# Altium schematic sheet esp32-dfplayer.SchDoc(esp32-dfplayer)
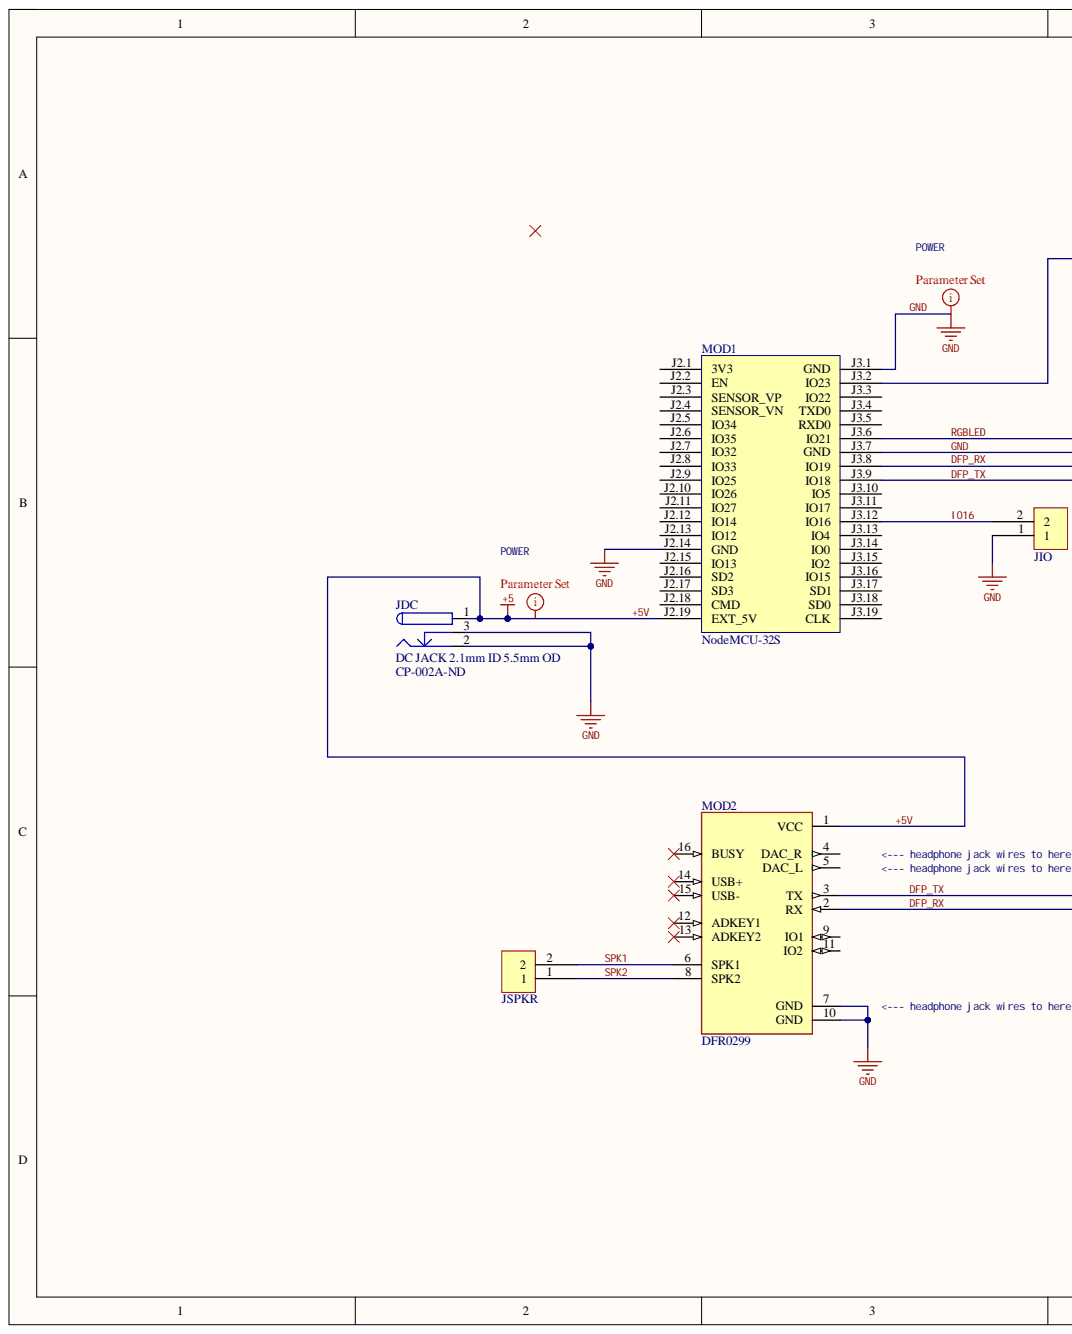
[diagram p0: esp32-dfplayer.SchDoc, left]
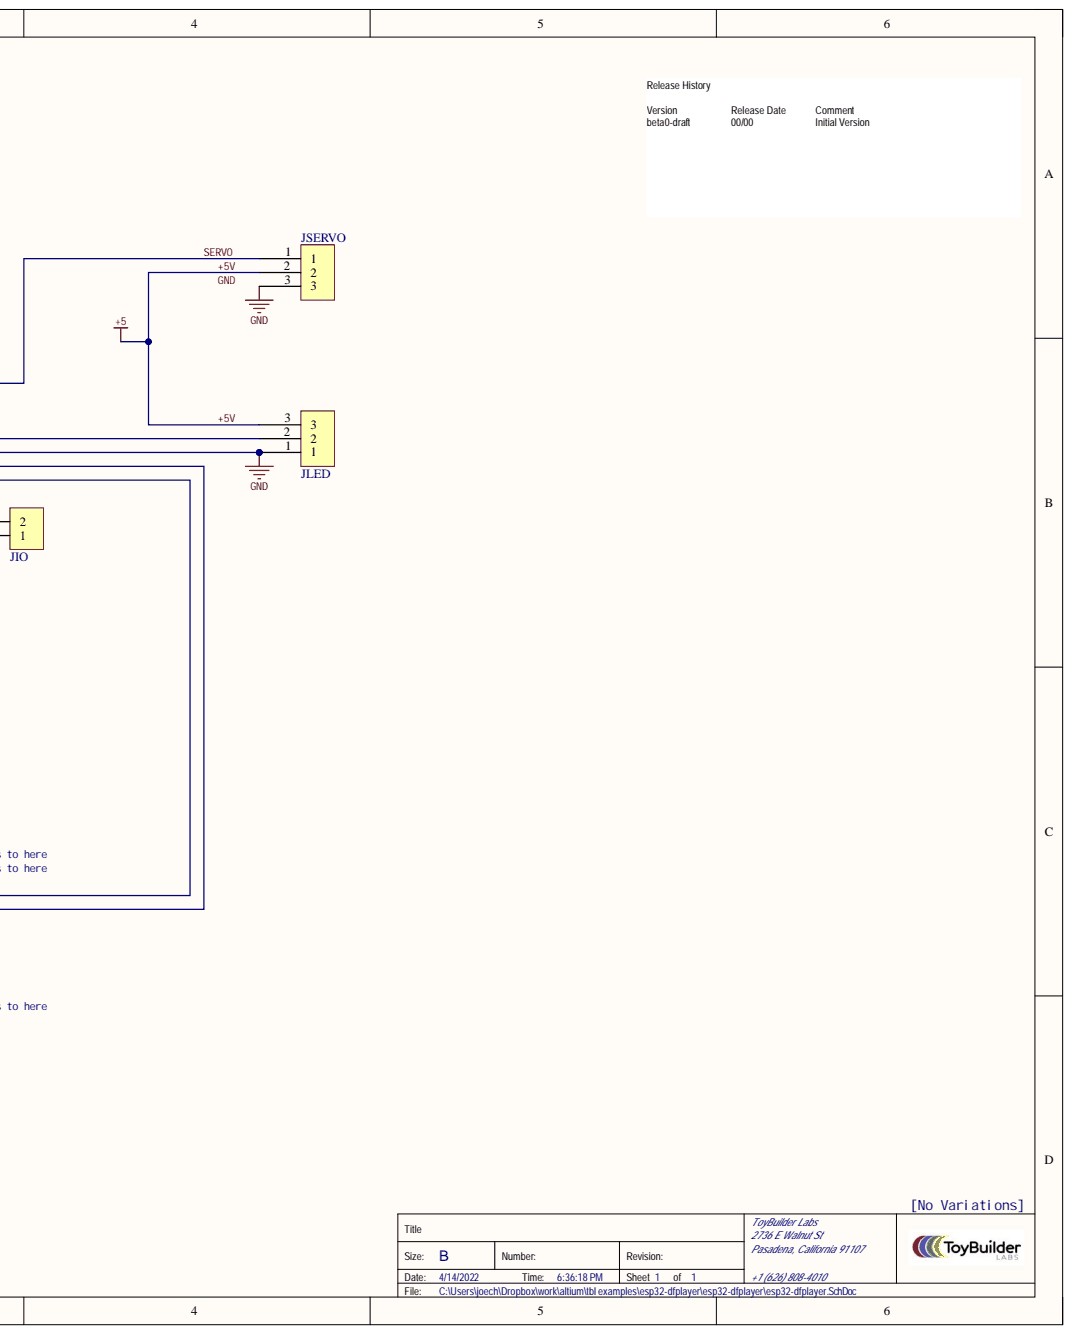
[diagram p1: esp32-dfplayer.SchDoc, right]

## Parts
JDC  DC JACK 2.1mm ID 5.5mm OD  Supplier Part Number 1: CP-002A-ND  [CUI_PJ002A_NOSLOT]
JIO  Header 2-Pin, 0.100 inch (0.230 inch head)  [HEADER_AA_002]  (value not drawn)
JLED  Header 3-Pin, 0.100 inch (0.230 inch head)  [HEADER_AA_003]  (value not drawn)
JSERVO  Header 3-Pin, 0.100 inch (0.230 inch head)  [HEADER_AA_003]  (value not drawn)
JSPKR  Header 2-Pin, 0.100 inch (0.230 inch head)  [HEADER_AA_002]  (value not drawn)
MOD1  NodeMCU-32S  [ESP32_NodeMCU-32S]
MOD2  DFR0299  [MODULE_DFR0299]

## Nets
+5V: JDC.1 JLED.3 JSERVO.2 MOD1.J2.19(EXT_5V) MOD2.1(VCC)
DFP_RX: MOD1.J3.8(IO19) MOD2.2(RX)
DFP_TX: MOD1.J3.9(IO18) MOD2.3(TX)
GND: JDC.2 JDC.3 JIO.1 JLED.1 JSERVO.3 MOD1.J2.14(GND) MOD1.J3.1(GND) MOD1.J3.7(GND) MOD2.7(GND) MOD2.10(GND)
IO16: JIO.2 MOD1.J3.12(IO16)
RGBLED: JLED.2 MOD1.J3.6(IO21)
SERVO: JSERVO.1 MOD1.J3.2(IO23)
SPK1: JSPKR.2 MOD2.6(SPK1)
SPK2: JSPKR.1 MOD2.8(SPK2)
NetMOD1_J2.1: MOD1.J2.1(3V3) MOD1.J2.10(IO26)

Not connected: MOD1 J2.2(EN) J2.3(SENSOR_VP) J2.4(SENSOR_VN) J2.5(IO34) J2.6(IO35) J2.7(IO32) J2.8(IO33) J2.9(IO25) J2.11(IO27) J2.12(IO14) J2.13(IO12) J2.15(IO13) J2.16(SD2) J2.17(SD3) J2.18(CMD) J3.3(IO22) J3.4(TXD0) J3.5(RXD0) J3.10(IO5) J3.11(IO17) J3.13(IO4) J3.14(IO0) J3.15(IO2) J3.16(IO15) J3.17(SD1) J3.18(SD0) J3.19(CLK); MOD2 4(DAC_R) 5(DAC_L) 9(IO1) 11(IO2) 12(ADKEY1) 13(ADKEY2) 14(USB+) 15(USB-) 16(BUSY)

## Library data of the parts
JDC = DCJACK_2.1x5.5: DC Barrel Jack, Female, 2.1 mm ID, 5.5 mm OD.; Manufacturer: CUI Inc.; Manufacturer Part Number: PJ-002A; Actual Diameter: 0.078" (2.00mm ID), 0.217" (5.50mm OD); Body Color: Black; Gender: Male; Industry Recognized Mating Diameter: 2.10mm ID (0.083"), 5.50mm OD (0.217"); Internal Switch(s): Single Switch, Normally Closed; Material Flammability Rating: UL94 V-0; Mating Length/Depth: 0.354" (9.00mm); Number of Positions/Contacts: 2 Conductors, 3 Contacts; Shielding: Unshielded; Voltage - Rated: 24VDC; Body Material: -; Category: Connectors, Interconnects; Component Kind: Standard; ComponentLink1Description: http://www.cui.com/product/resource/digikeypdf/pj-002a.pdf; ComponentLink1URL: http://www.cui.com/product/resource/digikeypdf/pj-002a.pdf; Connector Type: Jack; Contact Material: Brass; Copper Alloy; Contact Material - Plating: Tin; Features: -; Housing Material: Polybutylene Terephthalate (PBT); Includes: -; Ingress Protection: -; Insulation Color: -; Library Name: TBL Connectors.SchLib; Mounting Feature: -; Mounting Type: Through Hole, Right Angle; Operating Temperature: -25°C ~ 85°C; Packaging: Bulk; Part Status: Active; Pricing 1: 1=0.6, 10=0.558, 25=0.5024, 50=0.4464, 100=0.4278, 250=0.3906, 500=0.372, 1000=0.3069, 2500=0.279 (USD); RoHS: RoHS Compliant; Signal Integrity: Connector; Stock 1: 114369; Supplier 1: Digi-Key; Termination: Solder Eyelet(s); Thread Size: -
JIO JSPKR = HEADER_AA_2: Header 2-Pin, 0.100 in; Component Kind: Standard; Function 1: Function 1; Function 2: Function 2; Library Name: TBLI-Headers.IntLib
JLED JSERVO = HEADER_AA_3: Header 3-Pin, 0.100 in; Component Kind: Standard; Function 1: Function 1; Function 2: Function 2; Library Name: TBLI-Headers.IntLib
MOD1 = ESP32_NodeMCU-32S: NodeMCU-32S Dev Board for ESP32; Component Kind: Standard; ComponentLink1Description: DevKitC Documentation; ComponentLink1URL: https://docs.espressif.com/projects/esp-idf/en/latest/get-started/get-started-devkitc.html; Library Name: TBL Modules.SchLib
MOD2 = DFR0299: MP3 Player Audio Arduino Platform Evaluation Expansion Board; MF: DFRobot; Availability: Unavailable; Component Kind: Standard; Library Name: DFR0299.IntLib; MP: DFR0299; Package: None; Price: None; SnapEDA_Link: https://www.snapeda.com/parts/DFR0299/DFRobot/view-part/588059/?ref=snap
